# Altium schematic sheet main.SchDoc(main) (continued)
## Library data of the parts (continued)
D3-D7 D11 = 0805 SMD RED LED: Single-color LEDs; LITEON; LTST-C170KRKT; Red; 130 °; 2 V; 0805; Manufacturer: Lite-On; Dominant Wavelength: 631nm; Forward Current: 30mA; Forward Voltage: 2.4V; Lens Color: Clear, Colorless; Lens Style: Clear; Luminous Intensity: 18mcd; Number of Circuits: 1; Peak Wavelength: 639nm; Power Dissipation: 75mW; Reverse Voltage: 5V; Shape: Rectangular; Test Current: 20mA; Viewing Angle: 130°; Case Code (Imperial): 0805; Case Code (Metric): 2012; Case/Package: 0805; Category: LEDs; China RoHS: Compliant; Color: Red; Component Kind: Standard; ComponentLink1Description: https://datasheet.octopart.com:443/LTST-C170KRKT-Lite-On-datasheet-26684674.pdf?src-supplier=Upverter; ComponentLink1URL: https://datasheet.octopart.com:443/LTST-C170KRKT-Lite-On-datasheet-26684674.pdf?src-supplier=Upverter; ComponentLink2Description: https://datasheet.octopart.com:443/LTST-C170KRKT-Lite-On-datasheet-180605146.pdf?src-supplier=IHS; ComponentLink2URL: https://datasheet.octopart.com:443/LTST-C170KRKT-Lite-On-datasheet-180605146.pdf?src-supplier=IHS; ComponentLink3Description: https://datasheet.octopart.com:443/LTST-C170KRKT-Lite-On-datasheet-158436.pdf?src-supplier=_legacy+Avnet; ComponentLink3URL: https://datasheet.octopart.com:443/LTST-C170KRKT-Lite-On-datasheet-158436.pdf?src-supplier=_legacy+Avnet; ComponentLink4Description: https://datasheet.octopart.com:443/LTST-C170KRKT-Lite-On-datasheet-157246157.pdf?src-supplier=ODG+(Origin+Data+Global); ComponentLink4URL: https://datasheet.octopart.com:443/LTST-C170KRKT-Lite-On-datasheet-157246157.pdf?src-supplier=ODG+(Origin+Data+Global); ComponentLink5Description: https://datasheet.octopart.com:443/LTST-C170KRKT-Lite-On-datasheet-166322636.pdf?src-supplier=TME; ComponentLink5URL: https://datasheet.octopart.com:443/LTST-C170KRKT-Lite-On-datasheet-166322636.pdf?src-supplier=TME; ComponentLink6Description: https://datasheet.octopart.com:443/LTST-C170KRKT-Lite-On-datasheet-165573808.pdf?src-supplier=TME; ComponentLink6URL: https://datasheet.octopart.com:443/LTST-C170KRKT-Lite-On-datasheet-165573808.pdf?src-supplier=TME; ComponentLink7Description: https://datasheet.octopart.com:443/LTST-C170KRKT-Lite-On-datasheet-8325281.pdf?src-supplier=Future+Electronics; ComponentLink7URL: https://datasheet.octopart.com:443/LTST-C170KRKT-Lite-On-datasheet-8325281.pdf?src-supplier=Future+Electronics; ComponentLink8Description: https://datasheet.octopart.com:443/LTST-C170KRKT-Lite-On-datasheet-94966.pdf?src-supplier=DigiKey; ComponentLink8URL: https://datasheet.octopart.com:443/LTST-C170KRKT-Lite-On-datasheet-94966.pdf?src-supplier=DigiKey; Depth: 1.6mm; Height: 1.1mm; Illumination Color: Red; Lead Free: Lead Free; Length: 3.2mm; Lens Transparency: Clear; Lifecycle Status: Production; Manufacturer Part Number: LTST-C170KRKT; Max Operating Temperature: 85°C; Min Operating Temperature: -55°C; Mounting Technology: Surface Mount; Number of Elements: 1; Number of LEDs: 1; Number of Terminals: 2; Packaging: Cut Tape; Pins: 2; Power Rating: 75mW; Pricing: 250=0,074, 500=0,063, 1250=0,053, 2500=0,05 (EUR); Radiation Hardening: No; REACH SVHC: Yes; RoHS: Compliant; Schedule B: 8541402000, 8541402000|8541402000|8541402000|8541402000|8541402000; Stock: 27100; Supplier: RS; Supplier Part Number: 6920916P; Terminal Pitch: 2.1mm; Wavelength: 631nm; Width: 1.25mm; Library Name: IMCODR Components.SchLib
  D3-D6: ClassName: LED_SUPPLIES
  D7 D11: ClassName: ESP32_CONN
D8 = 2053-07-SM-RPLF: Surge Arrestor 2-Electrode Miniature Gas Discharge Tube 75VDC 5kA SMD T/R; Manufacturer: Bourns; Fail Short: No; Number of Circuits: 1; Number of Poles: 2; Peak Pulse Current: 3kA; Tolerance: 20%; Case/Package: SMD/SMT; Category: Circuit Protection; China RoHS: Compliant; ClassName: HV_CURRENT_SENSE; Component Kind: Standard; ComponentLink1Description: https://datasheet.octopart.com:443/2053-07-SM-RPLF-Bourns-datasheet-166511578.pdf?src-supplier=IHS; ComponentLink1URL: https://datasheet.octopart.com:443/2053-07-SM-RPLF-Bourns-datasheet-166511578.pdf?src-supplier=IHS; ComponentLink2Description: https://datasheet.octopart.com:443/2053-07-SM-RPLF-Bourns-datasheet-47988657.pdf?src-supplier=Electro+Sonic; ComponentLink2URL: https://datasheet.octopart.com:443/2053-07-SM-RPLF-Bourns-datasheet-47988657.pdf?src-supplier=Electro+Sonic; ComponentLink3Description: https://datasheet.octopart.com:443/2053-07-SM-RPLF-Bourns-datasheet-94104123.pdf?src-supplier=Farnell; ComponentLink3URL: https://datasheet.octopart.com:443/2053-07-SM-RPLF-Bourns-datasheet-94104123.pdf?src-supplier=Farnell; ComponentLink4Description: https://datasheet.octopart.com:443/2053-07-SM-RPLF-Bourns-datasheet-11980459.pdf?src-supplier=Newark; ComponentLink4URL: https://datasheet.octopart.com:443/2053-07-SM-RPLF-Bourns-datasheet-11980459.pdf?src-supplier=Newark; ComponentLink5Description: http://sigma.octopart.com:80/conflict_mineral_statement/Bourns-company-36.pdf?src-supplier=Compliance+Statements; ComponentLink5URL: http://sigma.octopart.com:80/conflict_mineral_statement/Bourns-company-36.pdf?src-supplier=Compliance+Statements; Contact Plating: Nickel; Depth: 4.2mm; Height: 4mm; Length: 4mm; Lifecycle Status: Production; Manufacturer Part Number: 2053-07-SM-RPLF; Max Operating Temperature: 85°C; Min Operating Temperature: -30°C; Mounting Technology: Surface Mount; Number of Terminals: 2; Pricing: 2700=0,323 (EUR); REACH SVHC: Yes; RoHS: Compliant; Stock: 2650; Supplier: RS; Supplier Part Number: 1728471; Termination: SMD/SMT; Library Name: IMCODR Components.SchLib
D9 = CDSOD323-T12SC: ESD protection diodes / TVS diodes; BOURNS; CDSOD323-T12SC; 29.5 V; 14 A; 12 V; 13.3 V; Breakdown Voltage: 13.3V; Clamping Voltage: 29.5V; Direction: Bidirectional; Element Configuration: Single; ESD Protection: Yes; Leakage Current: 1µA; Number of Bidirectional Channels: 1; Peak Pulse Current: 14A; Peak Pulse Power: 400W; Power Line Protection: No; Reverse Standoff Voltage: 12V; Test Current: 1mA; Value: 50pF; Category: TVS Diodes; China RoHS: Compliant; ClassName: HV_CURRENT_SENSE; Component Kind: Standard; ComponentLink1Description: https://datasheet.octopart.com:443/CDSOD323-T12SC-Bourns-datasheet-179966067.pdf?src-supplier=Newark; ComponentLink1URL: https://datasheet.octopart.com:443/CDSOD323-T12SC-Bourns-datasheet-179966067.pdf?src-supplier=Newark; ComponentLink2Description: https://datasheet.octopart.com:443/CDSOD323-T12SC-Bourns-datasheet-37162147.pdf?src-supplier=Newark; ComponentLink2URL: https://datasheet.octopart.com:443/CDSOD323-T12SC-Bourns-datasheet-37162147.pdf?src-supplier=Newark; ComponentLink3Description: https://datasheet.octopart.com:443/CDSOD323-T12SC-Bourns-datasheet-134530207.pdf?src-supplier=Electro+Sonic; ComponentLink3URL: https://datasheet.octopart.com:443/CDSOD323-T12SC-Bourns-datasheet-134530207.pdf?src-supplier=Electro+Sonic; ComponentLink4Description: https://datasheet.octopart.com:443/CDSOD323-T12SC-Bourns-datasheet-11734656.pdf?src-supplier=Burklin+Elektronik; ComponentLink4URL: https://datasheet.octopart.com:443/CDSOD323-T12SC-Bourns-datasheet-11734656.pdf?src-supplier=Burklin+Elektronik; ComponentLink5Description: https://datasheet.octopart.com:443/CDSOD323-T12SC-Bourns-datasheet-114748516.pdf?src-supplier=TME; ComponentLink5URL: https://datasheet.octopart.com:443/CDSOD323-T12SC-Bourns-datasheet-114748516.pdf?src-supplier=TME; ComponentLink6Description: http://sigma.octopart.com:80/conflict_mineral_statement/Bourns-company-36.pdf?src-supplier=Compliance+Statements; ComponentLink6URL: http://sigma.octopart.com:80/conflict_mineral_statement/Bourns-company-36.pdf?src-supplier=Compliance+Statements; Composition: Zener; Contact Plating: Tin; Depth: 1.45mm; Height: 1.14mm; Length: 1.9mm; Lifecycle Status: Production; Manufacturer: Bourns; Manufacturer Part Number: CDSOD323-T12SC; Max Operating Temperature: 150°C; Max Reverse Leakage Current: 1µA; Min Breakdown Voltage: 13.3V; Min Operating Temperature: -55°C; Mounting Technology: Surface Mount; Number of Channels: 1; Number of Elements: 1; Number of Terminals: 2; Packaging: Digi-Reel®; Peak Reverse Current: 1µA; Pins: 2; Polarity: Bidirectional; Pricing: 1=0,685, 10=0,565, 100=0,397, 500=0,325, 1000=0,297, 5000=0,236 (EUR); Radiation Hardening: No; REACH SVHC: Yes; RoHS: Compliant; Stock: 9496; Supplier: Farnell; Supplier Part Number: 1824865; Termination: SMD/SMT; Working Voltage: 12V; Library Name: IMCODR Components.SchLib
D10 = 0805 SMD GREEN: OPTOELECTRONICS - SMD Chip LED - TopView Single Color Chip LED, Green, 571 at 20mA, 18 to approx. 71 at 20mA; Manufacturer: Lite-On; Manufacturer Part Number: LTST-C170KGKT; Dominant Wavelength: 571nm; Forward Current: 30mA; Forward Voltage: 2.4V; Lens Color: Clear, Colorless; Lens Style: Clear; Luminous Intensity: 35mcd; Number of Circuits: 1; Peak Wavelength: 574nm; Power Dissipation: 75mW; Reverse Voltage: 5V; Shape: Rectangular; Viewing Angle: 130°; Case Code (Imperial): 0805; Case Code (Metric): 2012; Case/Package: 0805; Category: LEDs; China RoHS: Compliant; ClassName: HV_SUPPLY; Color: Green; Component Kind: Standard; ComponentLink1Description: https://datasheet.octopart.com:443/LTST-C170KGKT-Lite-On-datasheet-26684672.pdf?src-supplier=Upverter; ComponentLink1URL: https://datasheet.octopart.com:443/LTST-C170KGKT-Lite-On-datasheet-26684672.pdf?src-supplier=Upverter; ComponentLink2Description: https://datasheet.octopart.com:443/LTST-C170KGKT-Lite-On-datasheet-141446389.pdf?src-supplier=ODG+(Origin+Data+Global); ComponentLink2URL: https://datasheet.octopart.com:443/LTST-C170KGKT-Lite-On-datasheet-141446389.pdf?src-supplier=ODG+(Origin+Data+Global); ComponentLink3Description: https://datasheet.octopart.com:443/LTST-C170KGKT-Lite-On-datasheet-180605145.pdf?src-supplier=IHS; ComponentLink3URL: https://datasheet.octopart.com:443/LTST-C170KGKT-Lite-On-datasheet-180605145.pdf?src-supplier=IHS; ComponentLink4Description: https://datasheet.octopart.com:443/LTST-C170KGKT-Lite-On-datasheet-158284.pdf?src-supplier=_legacy+Avnet; ComponentLink4URL: https://datasheet.octopart.com:443/LTST-C170KGKT-Lite-On-datasheet-158284.pdf?src-supplier=_legacy+Avnet; ComponentLink5Description: https://datasheet.octopart.com:443/LTST-C170KGKT-Lite-On-datasheet-166322636.pdf?src-supplier=TME; ComponentLink5URL: https://datasheet.octopart.com:443/LTST-C170KGKT-Lite-On-datasheet-166322636.pdf?src-supplier=TME; ComponentLink6Description: https://datasheet.octopart.com:443/LTST-C170KGKT-Lite-On-datasheet-165573808.pdf?src-supplier=TME; ComponentLink6URL: https://datasheet.octopart.com:443/LTST-C170KGKT-Lite-On-datasheet-165573808.pdf?src-supplier=TME; ComponentLink7Description: https://datasheet.octopart.com:443/LTST-C170KGKT-Lite-On-datasheet-137080776.pdf?src-supplier=X-ON; ComponentLink7URL: https://datasheet.octopart.com:443/LTST-C170KGKT-Lite-On-datasheet-137080776.pdf?src-supplier=X-ON; ComponentLink8Description: https://datasheet.octopart.com:443/LTST-C170KGKT-Lite-On-datasheet-68907.pdf?src-supplier=DigiKey; ComponentLink8URL: https://datasheet.octopart.com:443/LTST-C170KGKT-Lite-On-datasheet-68907.pdf?src-supplier=DigiKey; ComponentLink9Description: https://datasheet.octopart.com:443/LTST-C170KGKT-Lite-On-datasheet-14174950.pdf?src-supplier=iiiC; ComponentLink9URL: https://datasheet.octopart.com:443/LTST-C170KGKT-Lite-On-datasheet-14174950.pdf?src-supplier=iiiC; Depth: 1.25mm; Height: 1.1mm; Illumination Color: Green; Lead Free: Lead Free; Length: 2mm; Lens Transparency: Clear; Lifecycle Status: Production; Max Operating Temperature: 85°C; Min Operating Temperature: -55°C; Mounting Technology: Surface Mount; Number of Elements: 1; Number of LEDs: 1; Number of Terminals: 2; Pins: 2; Power Rating: 75mW; Pricing: 50=0,178, 250=0,082, 500=0,07, 1250=0,06, 2500=0,056 (EUR); Radiation Hardening: No; REACH SVHC: Yes; RoHS: Compliant; Schedule B: 8541402000, 8541402000|8541402000|8541402000|8541402000|8541402000; Stock: 7050; Supplier: RS; Supplier Part Number: 6920907; Terminal Pitch: 2.1mm; Test Current: 20mA; Wavelength: 571nm; Width: 1.25mm; Library Name: IMCODR Components.SchLib
D12 = SS22-E3/5BT: Rectifier Diode, Schottky, 1 Phase, 1 Element, 2A, 20V V(RRM), Silicon, DO-214AA; Manufacturer: Vishay; Element Configuration: Single; Forward Voltage: 500mV; Max Forward Surge Current (Ifsm): 75A; Max Reverse Leakage Current: 400µA; Number of Elements: 1; Average Rectified Current: 2A; Case/Package: SMB; Category: Rectifier Diodes; China RoHS: Non-Compliant; ClassName: POWER_INPUT; Component Kind: Standard; ComponentLink1Description: https://datasheet.octopart.com:443/SS22-E3-5BT-Vishay-datasheet-181475076.pdf?src-supplier=IHS; ComponentLink1URL: https://datasheet.octopart.com:443/SS22-E3-5BT-Vishay-datasheet-181475076.pdf?src-supplier=IHS; ComponentLink2Description: https://datasheet.octopart.com:443/SS22-E3-5BT-Vishay-datasheet-65864839.pdf?src-supplier=Future+Electronics; ComponentLink2URL: https://datasheet.octopart.com:443/SS22-E3-5BT-Vishay-datasheet-65864839.pdf?src-supplier=Future+Electronics; ComponentLink3Description: https://datasheet.octopart.com:443/SS22-E3-5BT-Vishay-datasheet-28809158.pdf?src-supplier=Future+Electronics; ComponentLink3URL: https://datasheet.octopart.com:443/SS22-E3-5BT-Vishay-datasheet-28809158.pdf?src-supplier=Future+Electronics; ComponentLink4Description: http://sigma.octopart.com:80/rohs_statement/Vishay-company-15.pdf?src-supplier=Compliance+Statements; ComponentLink4URL: http://sigma.octopart.com:80/rohs_statement/Vishay-company-15.pdf?src-supplier=Compliance+Statements; Contact Plating: Tin; Forward Current: 2A; Lead Free: Lead Free; Lifecycle Status: Production; Manufacturer Part Number: SS22-E3/5BT; Max Operating Temperature: 125°C; Max Output current: 2A; Max Repetitive Reverse Voltage (Vrrm): 20V; Max Reverse Voltage (DC): 20V; Max Surge Current: 75A; Min Operating Temperature: -65°C; Mounting Technology: Surface Mount; Number of Terminals: 2; Peak Non-Repetitive Surge Current: 75A; Peak Reverse Current: 400µA; Pins: 2; Pricing: 1=0,5, 10=0,343, 100=0,2835, 500=0,21554, 1000=0,19348 (USD); Radiation Hardening: No; REACH SVHC: No; Reverse Standoff Voltage: 20V; RoHS: Compliant; Stock: 3106; Supplier: DigiKey; Supplier Part Number: 112-SS22-E3/5BTCT-ND; Library Name: IMCODR Components.SchLib
ENC1 = PEC11R-4215K-S0024: Mechanical Encoder Rotary Incremental Knurl 0.007 Straight Quadrature Digital Square Wave 24PPR Through Hole PC Pin; Manufacturer: Bourns; Built in Switch: Yes; Current Rating: 10mA; Hole Diameter: 1.8mm; Max Supply Voltage (DC): 5V; Number of Channels: 2; Number of Positions: 24; Output Type: Incremental, Quadrature; Category: Encoders; China RoHS: Compliant; ClassName: ROTARY_ENCODER; Component Kind: Standard; ComponentLink1Description: https://datasheet.octopart.com:443/PEC11R-4215K-S0024-Bourns-datasheet-152588733.pdf?src-supplier=IHS; ComponentLink1URL: https://datasheet.octopart.com:443/PEC11R-4215K-S0024-Bourns-datasheet-152588733.pdf?src-supplier=IHS; ComponentLink2Description: https://datasheet.octopart.com:443/PEC11R-4215K-S0024-Bourns-datasheet-68303416.pdf?src-supplier=Burklin+Elektronik; ComponentLink2URL: https://datasheet.octopart.com:443/PEC11R-4215K-S0024-Bourns-datasheet-68303416.pdf?src-supplier=Burklin+Elektronik; ComponentLink3Description: https://datasheet.octopart.com:443/PEC11R-4215K-S0024-Bourns-datasheet-37162171.pdf?src-supplier=element14+APAC; ComponentLink3URL: https://datasheet.octopart.com:443/PEC11R-4215K-S0024-Bourns-datasheet-37162171.pdf?src-supplier=element14+APAC; ComponentLink4Description: https://datasheet.octopart.com:443/PEC11R-4215K-S0024-Bourns-datasheet-37162172.pdf?src-supplier=TME; ComponentLink4URL: https://datasheet.octopart.com:443/PEC11R-4215K-S0024-Bourns-datasheet-37162172.pdf?src-supplier=TME; ComponentLink5Description: https://datasheet.octopart.com/PEC11R-4215K-S0024-Bourns-datasheet-16722594.pdf; ComponentLink5URL: https://datasheet.octopart.com/PEC11R-4215K-S0024-Bourns-datasheet-16722594.pdf; ComponentLink6Description: http://sigma.octopart.com:80/conflict_mineral_statement/Bourns-company-36.pdf?src-supplier=Compliance+Statements; ComponentLink6URL: http://sigma.octopart.com:80/conflict_mineral_statement/Bourns-company-36.pdf?src-supplier=Compliance+Statements; Detent: Yes; Encoder Type: Incremental; Height: 22.3mm; Housing Material: Metal; Length: 21.5mm; Lifecycle Status: Production; Manufacturer Part Number: PEC11R-4215K-S0024; Max Operating Temperature: 70°C; Min Operating Temperature: -30°C; Mounting Technology: PCB, Panel, Through Hole; Orientation: Vertical; Pricing: 10=1,66, 25=1,56, 100=1,19, 250=1,14 (EUR); Pulses per Revolution: 24; REACH SVHC: Yes; RoHS: Compliant; Schedule B: 8543709655|8543709655|8543709655|8543709655|8543709655; Stock: 710; Supplier: RS; Supplier Part Number: 7377742P; Termination: PC Pin; Voltage Rating (DC): 5V; Width: 12.5mm; Library Name: IMCODR Components.SchLib
HV1 = BPx20504: iSeg BPS series 1/3W High-Voltage supply; ClassName: HV_SUPPLY; Component Kind: Standard; Library Name: IMCODR Components.SchLib
J1 = TBLK4_5P Wurth Elektronik 691137710004: Terminal Block, 5MM Pitch, Horizontal, Pressure Clamp Glow Wire, Pcb, 4PINS, Gr; Category: Terminal Blocks; ClassName: POWER_INPUT; Component Kind: Standard; ComponentLink1Description: https://datasheet.octopart.com:443/691137710004-W%C3%BCrth-Elektronik-datasheet-117282627.pdf?src-supplier=Wurth+Elektronik; ComponentLink1URL: https://datasheet.octopart.com:443/691137710004-W%C3%BCrth-Elektronik-datasheet-117282627.pdf?src-supplier=Wurth+Elektronik; ComponentLink2Description: https://datasheet.octopart.com:443/691137710004-Wurth-Elektronik-datasheet-166323114.pdf?src-supplier=Newark; ComponentLink2URL: https://datasheet.octopart.com:443/691137710004-Wurth-Elektronik-datasheet-166323114.pdf?src-supplier=Newark; Lead Pitch: 5mm; Manufacturer: Wurth Elektronik; Manufacturer Part Number: 691137710004; Packaging: Box; Pins: 4; Pricing: 5=0,73, 25=0,658, 50=0,6, 100=0,548, 250=0,502 (EUR); RoHS: Non-Compliant; Stock: 174; Supplier: RS; Supplier Part Number: 1770041; Library Name: IMCODR Components.SchLib
J2 J3 = CON19X1_2P54: PCB Receptacle, Board-to-Board, 2.54 mm, 1 Rows, 19 Contacts, Through Hole Mount, SSW Series; Manufacturer: Samtec; Manufacturer Lifecycle Status: PRODUCTION; Manufacturer Part Number: SSW-119-01-T-S; Connector Type: Receptacle, Socket; Lead Length: 2.64mm; Number of Contacts: 19; Pitch: 2.54mm; Voltage Rating (AC): 465V; Voltage Rating (DC): 655V; Category: Connectors; ClassName: ESP32_CONN; Color: Black; Component Kind: Standard; ComponentLink1Description: https://datasheet.octopart.com:443/SSW-119-01-T-S-Samtec-datasheet-97292260.pdf?src-supplier=Samtec; ComponentLink1URL: https://datasheet.octopart.com:443/SSW-119-01-T-S-Samtec-datasheet-97292260.pdf?src-supplier=Samtec; ComponentLink2Description: https://sigma.octopart.com:443/97292261/technical_drawing/Samtec-SSW-119-01-T-S.pdf?src-supplier=Samtec; ComponentLink2URL: https://sigma.octopart.com:443/97292261/technical_drawing/Samtec-SSW-119-01-T-S.pdf?src-supplier=Samtec; ComponentLink3Description: https://datasheet.octopart.com:443/SSW-119-01-T-S-Samtec-datasheet-97291133.pdf?src-supplier=element14+APAC; ComponentLink3URL: https://datasheet.octopart.com:443/SSW-119-01-T-S-Samtec-datasheet-97291133.pdf?src-supplier=element14+APAC; ComponentLink4Description: https://datasheet.octopart.com:443/SSW-119-01-T-S-Samtec-datasheet-184263327.pdf?src-supplier=Electro+Sonic; ComponentLink4URL: https://datasheet.octopart.com:443/SSW-119-01-T-S-Samtec-datasheet-184263327.pdf?src-supplier=Electro+Sonic; ComponentLink5Description: https://datasheet.octopart.com:443/SSW-119-01-T-S-Samtec-datasheet-171398496.pdf?src-supplier=JRH+Electronics; ComponentLink5URL: https://datasheet.octopart.com:443/SSW-119-01-T-S-Samtec-datasheet-171398496.pdf?src-supplier=JRH+Electronics; ComponentLink6Description: https://datasheet.octopart.com:443/SSW-119-01-T-S-Samtec-datasheet-153594224.pdf?src-supplier=Sager+Electronics; ComponentLink6URL: https://datasheet.octopart.com:443/SSW-119-01-T-S-Samtec-datasheet-153594224.pdf?src-supplier=Sager+Electronics; ComponentLink7Description: https://datasheet.octopart.com:443/SSW-119-01-T-S-Samtec-datasheet-118235309.pdf?src-supplier=Sager+Electronics; ComponentLink7URL: https://datasheet.octopart.com:443/SSW-119-01-T-S-Samtec-datasheet-118235309.pdf?src-supplier=Sager+Electronics; ComponentLink8Description: https://datasheet.octopart.com/SSW-119-01-T-S-Samtec-datasheet-86818373.pdf; ComponentLink8URL: https://datasheet.octopart.com/SSW-119-01-T-S-Samtec-datasheet-86818373.pdf; ComponentLink9Description: https://datasheet.octopart.com/SSW-119-01-T-S-Samtec-datasheet-17059784.pdf; ComponentLink9URL: https://datasheet.octopart.com/SSW-119-01-T-S-Samtec-datasheet-17059784.pdf; ComponentLink10Description: https://datasheet.octopart.com:443/SSW-119-01-T-S-Samtec-datasheet-62331924.pdf?src-supplier=Newark; ComponentLink10URL: https://datasheet.octopart.com:443/SSW-119-01-T-S-Samtec-datasheet-62331924.pdf?src-supplier=Newark; ComponentLink11Description: https://datasheet.octopart.com:443/SSW-119-01-T-S-Samtec-datasheet-138830832.pdf?src-supplier=Newark; ComponentLink11URL: https://datasheet.octopart.com:443/SSW-119-01-T-S-Samtec-datasheet-138830832.pdf?src-supplier=Newark; ComponentLink12Description: https://datasheet.octopart.com:443/SSW-119-01-T-S-Samtec-datasheet-62317613.pdf?src-supplier=Newark; ComponentLink12URL: https://datasheet.octopart.com:443/SSW-119-01-T-S-Samtec-datasheet-62317613.pdf?src-supplier=Newark; ComponentLink13Description: https://datasheet.octopart.com:443/SSW-119-01-T-S-Samtec-datasheet-21214641.pdf?src-supplier=Newark; ComponentLink13URL: https://datasheet.octopart.com:443/SSW-119-01-T-S-Samtec-datasheet-21214641.pdf?src-supplier=Newark; ComponentLink14Description: https://datasheet.octopart.com:443/SSW-119-01-T-S-Samtec-datasheet-21424861.pdf?src-supplier=Farnell; ComponentLink14URL: https://datasheet.octopart.com:443/SSW-119-01-T-S-Samtec-datasheet-21424861.pdf?src-supplier=Farnell; Contact Material: Bronze; Contact Plating: Tin; Gender: Receptacle; Height: 8.51mm; Housing Color: Black; Housing Material: Plastic; LatestRevisionDate: 17-Jul-2002; LatestRevisionNote: Re-released for DXP Platform.; Lead Free: Lead Free; Lead Pitch: 2.54mm; Length: 48.8mm; Lifecycle Status: Production; Mounting Technology: Through Hole; Number of Positions: 19; Number of Rows: 1; Packaging: Bulk; Pricing: 1=2,33, 10=1,91, 100=1,61, 250=1,53, 500=1,44 (EUR); REACH SVHC: No; RoHS: Compliant; Signal Integrity: Connector; Stock: 109; Supplier: Farnell; Supplier Part Number: 3661308; Termination: Solder; Width: 2.41mm; Library Name: IMCODR Components.SchLib; Publisher: Altium Limited
J4 J5 = HV Connection Hole: Solder-on cable connector for HV; ClassName: HV_SUPPLY; Component Kind: Standard; Library Name: IMCODR Components.SchLib
J6 J8 = CON5X1_2P54: Pin Header, Vertical, Board-to-Board, 2.54 mm, 1 Rows, 5 Contacts, Through Hole, TSW; Manufacturer: Samtec; Manufacturer Lifecycle Status: PRODUCTION; Manufacturer Part Number: TSW-105-07-L-S; Connector Type: Male Pin; Current Rating: 7.6A; Gender: Male; Max Voltage Rating (AC): 550V; Number of Contacts: 5; Pitch: 2.54mm; Category: Headers and Wire Housings; Color: Black; Component Kind: Standard; ComponentLink1Description: https://datasheet.octopart.com:443/TSW-105-07-L-S-Samtec-datasheet-97325576.pdf?src-supplier=Sager+Electronics; ComponentLink1URL: https://datasheet.octopart.com:443/TSW-105-07-L-S-Samtec-datasheet-97325576.pdf?src-supplier=Sager+Electronics; ComponentLink2Description: https://datasheet.octopart.com:443/TSW-105-07-L-S-Samtec-datasheet-151455181.pdf?src-supplier=Sager+Electronics; ComponentLink2URL: https://datasheet.octopart.com:443/TSW-105-07-L-S-Samtec-datasheet-151455181.pdf?src-supplier=Sager+Electronics; ComponentLink3Description: https://sigma.octopart.com:443/97325577/technical_drawing/Samtec-TSW-105-07-L-S.pdf?src-supplier=Samtec; ComponentLink3URL: https://sigma.octopart.com:443/97325577/technical_drawing/Samtec-TSW-105-07-L-S.pdf?src-supplier=Samtec; ComponentLink4Description: https://datasheet.octopart.com:443/TSW-105-07-L-S-Samtec-datasheet-62107121.pdf?src-supplier=Farnell; ComponentLink4URL: https://datasheet.octopart.com:443/TSW-105-07-L-S-Samtec-datasheet-62107121.pdf?src-supplier=Farnell; ComponentLink5Description: https://datasheet.octopart.com:443/TSW-105-07-L-S-Samtec-datasheet-136646178.pdf?src-supplier=Master+Electronics; ComponentLink5URL: https://datasheet.octopart.com:443/TSW-105-07-L-S-Samtec-datasheet-136646178.pdf?src-supplier=Master+Electronics; ComponentLink6Description: https://datasheet.octopart.com:443/TSW-105-07-L-S-Samtec-datasheet-116615235.pdf?src-supplier=Master+Electronics; ComponentLink6URL: https://datasheet.octopart.com:443/TSW-105-07-L-S-Samtec-datasheet-116615235.pdf?src-supplier=Master+Electronics; ComponentLink7Description: https://datasheet.octopart.com:443/TSW-105-07-L-S-Samtec-datasheet-182948906.pdf?src-supplier=JRH+Electronics; ComponentLink7URL: https://datasheet.octopart.com:443/TSW-105-07-L-S-Samtec-datasheet-182948906.pdf?src-supplier=JRH+Electronics; ComponentLink8Description: https://datasheet.octopart.com:443/TSW-105-07-L-S-Samtec-datasheet-160657603.pdf?src-supplier=Toby+Electronics; ComponentLink8URL: https://datasheet.octopart.com:443/TSW-105-07-L-S-Samtec-datasheet-160657603.pdf?src-supplier=Toby+Electronics; ComponentLink9Description: https://datasheet.octopart.com:443/TSW-105-07-L-S-Samtec-datasheet-116539033.pdf?src-supplier=Toby+Electronics; ComponentLink9URL: https://datasheet.octopart.com:443/TSW-105-07-L-S-Samtec-datasheet-116539033.pdf?src-supplier=Toby+Electronics; ComponentLink10Description: https://datasheet.octopart.com:443/TSW-105-07-L-S-Samtec-datasheet-116536310.pdf?src-supplier=Toby+Electronics; ComponentLink10URL: https://datasheet.octopart.com:443/TSW-105-07-L-S-Samtec-datasheet-116536310.pdf?src-supplier=Toby+Electronics; ComponentLink11Description: https://datasheet.octopart.com:443/TSW-105-07-L-S-Samtec-datasheet-118235302.pdf?src-supplier=Electro+Sonic; ComponentLink11URL: https://datasheet.octopart.com:443/TSW-105-07-L-S-Samtec-datasheet-118235302.pdf?src-supplier=Electro+Sonic; ComponentLink12Description: https://datasheet.octopart.com/TSW-105-07-L-S-Samtec-datasheet-86818365.pdf; ComponentLink12URL: https://datasheet.octopart.com/TSW-105-07-L-S-Samtec-datasheet-86818365.pdf; ComponentLink13Description: https://datasheet.octopart.com:443/TSW-105-07-L-S-Samtec-datasheet-11047283.pdf?src-supplier=Newark; ComponentLink13URL: https://datasheet.octopart.com:443/TSW-105-07-L-S-Samtec-datasheet-11047283.pdf?src-supplier=Newark; Contact Material: Bronze; Contact Mating Length: 5.842mm; Contact Plating: Gold; Depth: 2.54mm; Housing Color: Black; Housing Material: Polyester; LatestRevisionDate: 17-Jul-2002; LatestRevisionNote: Re-released for DXP Platform.; Lead Free: Lead Free; Lead Pitch: 2.54mm; Length: 12.7mm; Lifecycle Status: Production; Max Current Rating: 7.6A; Max Operating Temperature: 125°C; Min Operating Temperature: -55°C; Mounting Technology: Through Hole; Number of Positions: 5; Number of Rows: 1; Orientation: Straight; Packaging: Bulk; Pricing: 10=0,379, 100=0,33, 500=0,289, 1000=0,24, 2500=0,181 (EUR); Radiation Hardening: No; REACH SVHC: No; RoHS: Compliant; Signal Integrity: Connector; Stock: 92; Supplier: Farnell; Supplier Part Number: 3515082; Termination: Solder; Voltage Rating: 550V; Width: 2.54mm; Library Name: IMCODR Components.SchLib; Publisher: Altium Limited
  J6: ClassName: ROTARY_ENCODER
  J8: ClassName: RS232_DEBUG
J7 = CON8X2_2P54: Pin Header, Board-to-Board, 2.54 mm, 2 Rows, 16 Contacts, Through Hole, TSW Series; Manufacturer: Samtec; Manufacturer Lifecycle Status: PRODUCTION; Manufacturer Part Number: TSW-108-07-L-D; Connector Type: Male Pin; Current Rating: 7.6A; Gender: Male; Max Voltage Rating (AC): 550V; Number of Contacts: 16; Pitch: 2.54mm; Category: Headers and Wire Housings; ClassName: IO_EXPANSION; Color: Black; Component Kind: Standard; ComponentLink1Description: https://datasheet.octopart.com:443/TSW-108-07-L-D-Samtec-datasheet-97325576.pdf?src-supplier=Sager+Electronics; ComponentLink1URL: https://datasheet.octopart.com:443/TSW-108-07-L-D-Samtec-datasheet-97325576.pdf?src-supplier=Sager+Electronics; ComponentLink2Description: https://datasheet.octopart.com:443/TSW-108-07-L-D-Samtec-datasheet-151455181.pdf?src-supplier=Sager+Electronics; ComponentLink2URL: https://datasheet.octopart.com:443/TSW-108-07-L-D-Samtec-datasheet-151455181.pdf?src-supplier=Sager+Electronics; ComponentLink3Description: https://sigma.octopart.com:443/97325577/technical_drawing/Samtec-TSW-108-07-L-D.pdf?src-supplier=Samtec; ComponentLink3URL: https://sigma.octopart.com:443/97325577/technical_drawing/Samtec-TSW-108-07-L-D.pdf?src-supplier=Samtec; ComponentLink4Description: https://datasheet.octopart.com:443/TSW-108-07-L-D-Samtec-datasheet-62107121.pdf?src-supplier=Farnell; ComponentLink4URL: https://datasheet.octopart.com:443/TSW-108-07-L-D-Samtec-datasheet-62107121.pdf?src-supplier=Farnell; ComponentLink5Description: https://datasheet.octopart.com:443/TSW-108-07-L-D-Samtec-datasheet-136646178.pdf?src-supplier=Master+Electronics; ComponentLink5URL: https://datasheet.octopart.com:443/TSW-108-07-L-D-Samtec-datasheet-136646178.pdf?src-supplier=Master+Electronics; ComponentLink6Description: https://datasheet.octopart.com:443/TSW-108-07-L-D-Samtec-datasheet-116615235.pdf?src-supplier=Electro+Sonic; ComponentLink6URL: https://datasheet.octopart.com:443/TSW-108-07-L-D-Samtec-datasheet-116615235.pdf?src-supplier=Electro+Sonic; ComponentLink7Description: https://datasheet.octopart.com:443/TSW-108-07-L-D-Samtec-datasheet-182948906.pdf?src-supplier=JRH+Electronics; ComponentLink7URL: https://datasheet.octopart.com:443/TSW-108-07-L-D-Samtec-datasheet-182948906.pdf?src-supplier=JRH+Electronics; ComponentLink8Description: https://datasheet.octopart.com:443/TSW-108-07-L-D-Samtec-datasheet-160657603.pdf?src-supplier=Toby+Electronics; ComponentLink8URL: https://datasheet.octopart.com:443/TSW-108-07-L-D-Samtec-datasheet-160657603.pdf?src-supplier=Toby+Electronics; ComponentLink9Description: https://datasheet.octopart.com:443/TSW-108-07-L-D-Samtec-datasheet-116539033.pdf?src-supplier=Toby+Electronics; ComponentLink9URL: https://datasheet.octopart.com:443/TSW-108-07-L-D-Samtec-datasheet-116539033.pdf?src-supplier=Toby+Electronics; ComponentLink10Description: https://datasheet.octopart.com:443/TSW-108-07-L-D-Samtec-datasheet-116536310.pdf?src-supplier=Toby+Electronics; ComponentLink10URL: https://datasheet.octopart.com:443/TSW-108-07-L-D-Samtec-datasheet-116536310.pdf?src-supplier=Toby+Electronics; ComponentLink11Description: https://datasheet.octopart.com/TSW-108-07-L-D-Samtec-datasheet-86818365.pdf; ComponentLink11URL: https://datasheet.octopart.com/TSW-108-07-L-D-Samtec-datasheet-86818365.pdf; ComponentLink12Description: https://datasheet.octopart.com:443/TSW-108-07-L-D-Samtec-datasheet-11047283.pdf?src-supplier=Newark; ComponentLink12URL: https://datasheet.octopart.com:443/TSW-108-07-L-D-Samtec-datasheet-11047283.pdf?src-supplier=Newark; Contact Material: Bronze; Contact Mating Length: 5.842mm; Contact Plating: Gold; Depth: 5.08mm; Housing Color: Black; Housing Material: Polyester; LatestRevisionDate: 17-Jul-2002; LatestRevisionNote: Re-released for DXP Platform.; Lead Free: Lead Free; Lead Pitch: 2.54mm; Length: 20.32mm; Lifecycle Status: Production; Max Current Rating: 7.6A; Max Operating Temperature: 125°C; Min Operating Temperature: -55°C; Mounting Technology: Through Hole; Number of Positions: 16; Number of Rows: 2; Orientation: Straight; Packaging: Bulk; Pricing: 1=1,06, 10=0,968, 100=0,876, 500=0,733, 1000=0,644 (EUR); Radiation Hardening: No; REACH SVHC: No; RoHS: Compliant; Row Spacing: 2.54mm; Signal Integrity: Connector; Stock: 97; Supplier: Farnell; Supplier Part Number: 2984454; Termination: Solder; Voltage Rating: 550V; Width: 5.08mm; Library Name: IMCODR Components.SchLib; Publisher: Altium Limited
J9 = CON4X1_2P54: Pin Header, Board-to-Board, 2.54 mm, 1 Rows, 4 Contacts, Through Hole, TSW; Manufacturer: Samtec; Manufacturer Lifecycle Status: PRODUCTION; Manufacturer Part Number: TSW-104-07-L-S; Connector Type: Male Pin; Current Rating: 7.6A; Gender: Male; Max Current Rating: 7A; Max Voltage Rating (AC): 550V; Number of Contacts: 4; Pitch: 2.54mm; Category: Headers and Wire Housings; ClassName: I2C_EXPANSION; Color: Black; Component Kind: Standard; ComponentLink1Description: https://datasheet.octopart.com:443/TSW-104-07-L-S-Samtec-datasheet-97325576.pdf?src-supplier=Newark; ComponentLink1URL: https://datasheet.octopart.com:443/TSW-104-07-L-S-Samtec-datasheet-97325576.pdf?src-supplier=Newark; ComponentLink2Description: https://datasheet.octopart.com:443/TSW-104-07-L-S-Samtec-datasheet-62107121.pdf?src-supplier=Newark; ComponentLink2URL: https://datasheet.octopart.com:443/TSW-104-07-L-S-Samtec-datasheet-62107121.pdf?src-supplier=Newark; ComponentLink3Description: https://datasheet.octopart.com:443/TSW-104-07-L-S-Samtec-datasheet-11047283.pdf?src-supplier=Newark; ComponentLink3URL: https://datasheet.octopart.com:443/TSW-104-07-L-S-Samtec-datasheet-11047283.pdf?src-supplier=Newark; ComponentLink4Description: https://sigma.octopart.com:443/97325577/technical_drawing/Samtec-TSW-104-07-L-S.pdf?src-supplier=Samtec; ComponentLink4URL: https://sigma.octopart.com:443/97325577/technical_drawing/Samtec-TSW-104-07-L-S.pdf?src-supplier=Samtec; ComponentLink5Description: https://datasheet.octopart.com:443/TSW-104-07-L-S-Samtec-datasheet-150856372.pdf?src-supplier=Electro+Sonic; ComponentLink5URL: https://datasheet.octopart.com:443/TSW-104-07-L-S-Samtec-datasheet-150856372.pdf?src-supplier=Electro+Sonic; ComponentLink6Description: https://datasheet.octopart.com:443/TSW-104-07-L-S-Samtec-datasheet-32864818.pdf?src-supplier=Electro+Sonic; ComponentLink6URL: https://datasheet.octopart.com:443/TSW-104-07-L-S-Samtec-datasheet-32864818.pdf?src-supplier=Electro+Sonic; ComponentLink7Description: https://datasheet.octopart.com:443/TSW-104-07-L-S-Samtec-datasheet-11542832.pdf?src-supplier=Electro+Sonic; ComponentLink7URL: https://datasheet.octopart.com:443/TSW-104-07-L-S-Samtec-datasheet-11542832.pdf?src-supplier=Electro+Sonic; ComponentLink8Description: https://datasheet.octopart.com:443/TSW-104-07-L-S-Samtec-datasheet-116615235.pdf?src-supplier=Master+Electronics; ComponentLink8URL: https://datasheet.octopart.com:443/TSW-104-07-L-S-Samtec-datasheet-116615235.pdf?src-supplier=Master+Electronics; ComponentLink9Description: https://datasheet.octopart.com:443/TSW-104-07-L-S-Samtec-datasheet-182948906.pdf?src-supplier=JRH+Electronics; ComponentLink9URL: https://datasheet.octopart.com:443/TSW-104-07-L-S-Samtec-datasheet-182948906.pdf?src-supplier=JRH+Electronics; ComponentLink10Description: https://datasheet.octopart.com:443/TSW-104-07-L-S-Samtec-datasheet-160657603.pdf?src-supplier=Toby+Electronics; ComponentLink10URL: https://datasheet.octopart.com:443/TSW-104-07-L-S-Samtec-datasheet-160657603.pdf?src-supplier=Toby+Electronics; ComponentLink11Description: https://datasheet.octopart.com:443/TSW-104-07-L-S-Samtec-datasheet-116539033.pdf?src-supplier=Toby+Electronics; ComponentLink11URL: https://datasheet.octopart.com:443/TSW-104-07-L-S-Samtec-datasheet-116539033.pdf?src-supplier=Toby+Electronics; ComponentLink12Description: https://datasheet.octopart.com:443/TSW-104-07-L-S-Samtec-datasheet-116536310.pdf?src-supplier=Toby+Electronics; ComponentLink12URL: https://datasheet.octopart.com:443/TSW-104-07-L-S-Samtec-datasheet-116536310.pdf?src-supplier=Toby+Electronics; ComponentLink13Description: https://datasheet.octopart.com:443/TSW-104-07-L-S-Samtec-datasheet-151455181.pdf?src-supplier=Sager+Electronics; ComponentLink13URL: https://datasheet.octopart.com:443/TSW-104-07-L-S-Samtec-datasheet-151455181.pdf?src-supplier=Sager+Electronics; ComponentLink14Description: https://datasheet.octopart.com/TSW-104-07-L-S-Samtec-datasheet-86818365.pdf; ComponentLink14URL: https://datasheet.octopart.com/TSW-104-07-L-S-Samtec-datasheet-86818365.pdf; Contact Material: Bronze; Contact Mating Length: 5.842mm; Contact Plating: Gold; Depth: 2.54mm; Housing Color: Black; Housing Material: Polyester; LatestRevisionDate: 17-Jul-2002; LatestRevisionNote: Re-released for DXP Platform.; Lead Free: Lead Free; Lead Pitch: 2.54mm; Length: 10.16mm; Lifecycle Status: Production; Max Operating Temperature: 125°C; Min Operating Temperature: -55°C; Mounting Technology: Through Hole; Number of Positions: 4; Number of Rows: 1; Orientation: Straight; Packaging: Bulk; Pricing: 10=0,299, 100=0,275, 500=0,241, 1000=0,22, 2500=0,193 (EUR); Radiation Hardening: No; REACH SVHC: No; RoHS: Compliant; Signal Integrity: Connector; Stock: 140; Supplier: Farnell; Supplier Part Number: 2856694; Termination: Solder; Voltage Rating: 550V; Width: 2.54mm; Library Name: IMCODR Components.SchLib; Publisher: Altium Limited
J10 = CON8X1_2P54: Pin Header, Board-to-Board, 2.54 mm, 1 Rows, 8 Contacts, Through Hole, TSW Series; Manufacturer: Samtec; Manufacturer Lifecycle Status: PRODUCTION; Manufacturer Part Number: TSW-108-07-L-S; Connector Type: Male Pin; Current Rating: 7.6A; Gender: Male; Max Voltage Rating (AC): 550V; Number of Contacts: 8; Pitch: 2.54mm; Category: Headers and Wire Housings; ClassName: TFT_CONN; Color: Black; Component Kind: Standard; ComponentLink1Description: https://datasheet.octopart.com:443/TSW-108-07-L-S-Samtec-datasheet-97325576.pdf?src-supplier=Sager+Electronics; ComponentLink1URL: https://datasheet.octopart.com:443/TSW-108-07-L-S-Samtec-datasheet-97325576.pdf?src-supplier=Sager+Electronics; ComponentLink2Description: https://datasheet.octopart.com:443/TSW-108-07-L-S-Samtec-datasheet-151455181.pdf?src-supplier=Sager+Electronics; ComponentLink2URL: https://datasheet.octopart.com:443/TSW-108-07-L-S-Samtec-datasheet-151455181.pdf?src-supplier=Sager+Electronics; ComponentLink3Description: https://sigma.octopart.com:443/97325577/technical_drawing/Samtec-TSW-108-07-L-S.pdf?src-supplier=Samtec; ComponentLink3URL: https://sigma.octopart.com:443/97325577/technical_drawing/Samtec-TSW-108-07-L-S.pdf?src-supplier=Samtec; ComponentLink4Description: https://datasheet.octopart.com:443/TSW-108-07-L-S-Samtec-datasheet-62107121.pdf?src-supplier=Farnell; ComponentLink4URL: https://datasheet.octopart.com:443/TSW-108-07-L-S-Samtec-datasheet-62107121.pdf?src-supplier=Farnell; ComponentLink5Description: https://datasheet.octopart.com:443/TSW-108-07-L-S-Samtec-datasheet-150856372.pdf?src-supplier=Electro+Sonic; ComponentLink5URL: https://datasheet.octopart.com:443/TSW-108-07-L-S-Samtec-datasheet-150856372.pdf?src-supplier=Electro+Sonic; ComponentLink6Description: https://datasheet.octopart.com:443/TSW-108-07-L-S-Samtec-datasheet-32864818.pdf?src-supplier=Electro+Sonic; ComponentLink6URL: https://datasheet.octopart.com:443/TSW-108-07-L-S-Samtec-datasheet-32864818.pdf?src-supplier=Electro+Sonic; ComponentLink7Description: https://datasheet.octopart.com:443/TSW-108-07-L-S-Samtec-datasheet-21214474.pdf?src-supplier=Electro+Sonic; ComponentLink7URL: https://datasheet.octopart.com:443/TSW-108-07-L-S-Samtec-datasheet-21214474.pdf?src-supplier=Electro+Sonic; ComponentLink8Description: https://datasheet.octopart.com:443/TSW-108-07-L-S-Samtec-datasheet-116615235.pdf?src-supplier=Master+Electronics; ComponentLink8URL: https://datasheet.octopart.com:443/TSW-108-07-L-S-Samtec-datasheet-116615235.pdf?src-supplier=Master+Electronics; ComponentLink9Description: https://datasheet.octopart.com:443/TSW-108-07-L-S-Samtec-datasheet-182948906.pdf?src-supplier=JRH+Electronics; ComponentLink9URL: https://datasheet.octopart.com:443/TSW-108-07-L-S-Samtec-datasheet-182948906.pdf?src-supplier=JRH+Electronics; ComponentLink10Description: https://datasheet.octopart.com:443/TSW-108-07-L-S-Samtec-datasheet-160657603.pdf?src-supplier=Toby+Electronics; ComponentLink10URL: https://datasheet.octopart.com:443/TSW-108-07-L-S-Samtec-datasheet-160657603.pdf?src-supplier=Toby+Electronics; ComponentLink11Description: https://datasheet.octopart.com:443/TSW-108-07-L-S-Samtec-datasheet-116539033.pdf?src-supplier=Toby+Electronics; ComponentLink11URL: https://datasheet.octopart.com:443/TSW-108-07-L-S-Samtec-datasheet-116539033.pdf?src-supplier=Toby+Electronics; ComponentLink12Description: https://datasheet.octopart.com:443/TSW-108-07-L-S-Samtec-datasheet-116536310.pdf?src-supplier=Toby+Electronics; ComponentLink12URL: https://datasheet.octopart.com:443/TSW-108-07-L-S-Samtec-datasheet-116536310.pdf?src-supplier=Toby+Electronics; ComponentLink13Description: https://datasheet.octopart.com/TSW-108-07-L-S-Samtec-datasheet-86818365.pdf; ComponentLink13URL: https://datasheet.octopart.com/TSW-108-07-L-S-Samtec-datasheet-86818365.pdf; ComponentLink14Description: https://datasheet.octopart.com:443/TSW-108-07-L-S-Samtec-datasheet-11047283.pdf?src-supplier=Newark; ComponentLink14URL: https://datasheet.octopart.com:443/TSW-108-07-L-S-Samtec-datasheet-11047283.pdf?src-supplier=Newark; ComponentLink15Description: https://datasheet.octopart.com:443/TSW-108-07-L-S-Samtec-datasheet-5313500.pdf?src-supplier=_legacy+Avnet; ComponentLink15URL: https://datasheet.octopart.com:443/TSW-108-07-L-S-Samtec-datasheet-5313500.pdf?src-supplier=_legacy+Avnet; Contact Material: Bronze; Contact Mating Length: 5.842mm; Contact Plating: Gold; Depth: 2.54mm; Housing Color: Black; Housing Material: Polyester; LatestRevisionDate: 17-Jul-2002; LatestRevisionNote: Re-released for DXP Platform.; Lead Free: Lead Free; Lead Pitch: 2.54mm; Length: 20.32mm; Lifecycle Status: Production; Max Current Rating: 7.6A; Max Operating Temperature: 125°C; Min Operating Temperature: -55°C; Mounting Technology: Through Hole; Number of Positions: 8; Number of Rows: 1; Orientation: Straight; Packaging: Bulk; Pricing: 10=0,854, 50=0,715, 100=0,642, 250=0,634, 500=0,53 (EUR); Radiation Hardening: No; REACH SVHC: No; RoHS: Compliant; Signal Integrity: Connector; Stock: 99; Supplier: Farnell; Supplier Part Number: 2984523; Termination: Solder; Voltage Rating: 550V; Width: 2.54mm; Library Name: IMCODR Components.SchLib; Publisher: Altium Limited
JP1-JP3 JP5 JP6 JP10 = CON2X1_2P54: Pin Header, Board-to-Board, 2.54 mm, 1 Rows, 2 Contacts, Through Hole, TSW Series; Manufacturer: Samtec; Manufacturer Lifecycle Status: PRODUCTION; Manufacturer Part Number: TSW-102-07-L-S; Connector Type: Male Pin; Current Rating: 7.6A; Gender: Male; Max Voltage Rating (AC): 550V; Number of Contacts: 2; Pitch: 2.54mm; Category: Headers and Wire Housings; Color: Black; Component Kind: Standard; ComponentLink1Description: https://datasheet.octopart.com:443/TSW-102-07-L-S-Samtec-datasheet-142046629.pdf?src-supplier=Upverter; ComponentLink1URL: https://datasheet.octopart.com:443/TSW-102-07-L-S-Samtec-datasheet-142046629.pdf?src-supplier=Upverter; ComponentLink2Description: https://sigma.octopart.com:443/14433679/technical_drawing/Samtec-TSW-102-07-L-S.pdf?src-supplier=Upverter; ComponentLink2URL: https://sigma.octopart.com:443/14433679/technical_drawing/Samtec-TSW-102-07-L-S.pdf?src-supplier=Upverter; ComponentLink3Description: https://datasheet.octopart.com:443/TSW-102-07-L-S-Samtec-datasheet-97325576.pdf?src-supplier=Newark; ComponentLink3URL: https://datasheet.octopart.com:443/TSW-102-07-L-S-Samtec-datasheet-97325576.pdf?src-supplier=Newark; ComponentLink4Description: https://datasheet.octopart.com:443/TSW-102-07-L-S-Samtec-datasheet-11047283.pdf?src-supplier=Newark; ComponentLink4URL: https://datasheet.octopart.com:443/TSW-102-07-L-S-Samtec-datasheet-11047283.pdf?src-supplier=Newark; ComponentLink5Description: https://sigma.octopart.com:443/97325577/technical_drawing/Samtec-TSW-102-07-L-S.pdf?src-supplier=Samtec; ComponentLink5URL: https://sigma.octopart.com:443/97325577/technical_drawing/Samtec-TSW-102-07-L-S.pdf?src-supplier=Samtec; ComponentLink6Description: https://datasheet.octopart.com:443/TSW-102-07-L-S-Samtec-datasheet-62107121.pdf?src-supplier=Farnell; ComponentLink6URL: https://datasheet.octopart.com:443/TSW-102-07-L-S-Samtec-datasheet-62107121.pdf?src-supplier=Farnell; ComponentLink7Description: https://datasheet.octopart.com:443/TSW-102-07-L-S-Samtec-datasheet-150856372.pdf?src-supplier=Electro+Sonic; ComponentLink7URL: https://datasheet.octopart.com:443/TSW-102-07-L-S-Samtec-datasheet-150856372.pdf?src-supplier=Electro+Sonic; ComponentLink8Description: https://datasheet.octopart.com:443/TSW-102-07-L-S-Samtec-datasheet-62282237.pdf?src-supplier=Electro+Sonic; ComponentLink8URL: https://datasheet.octopart.com:443/TSW-102-07-L-S-Samtec-datasheet-62282237.pdf?src-supplier=Electro+Sonic; ComponentLink9Description: https://datasheet.octopart.com:443/TSW-102-07-L-S-Samtec-datasheet-41642934.pdf?src-supplier=Electro+Sonic; ComponentLink9URL: https://datasheet.octopart.com:443/TSW-102-07-L-S-Samtec-datasheet-41642934.pdf?src-supplier=Electro+Sonic; ComponentLink10Description: https://datasheet.octopart.com:443/TSW-102-07-L-S-Samtec-datasheet-32864818.pdf?src-supplier=Electro+Sonic; ComponentLink10URL: https://datasheet.octopart.com:443/TSW-102-07-L-S-Samtec-datasheet-32864818.pdf?src-supplier=Electro+Sonic; ComponentLink11Description: https://datasheet.octopart.com:443/TSW-102-07-L-S-Samtec-datasheet-116615235.pdf?src-supplier=Master+Electronics; ComponentLink11URL: https://datasheet.octopart.com:443/TSW-102-07-L-S-Samtec-datasheet-116615235.pdf?src-supplier=Master+Electronics; ComponentLink12Description: https://datasheet.octopart.com:443/TSW-102-07-L-S-Samtec-datasheet-180258786.pdf?src-supplier=JRH+Electronics; ComponentLink12URL: https://datasheet.octopart.com:443/TSW-102-07-L-S-Samtec-datasheet-180258786.pdf?src-supplier=JRH+Electronics; ComponentLink13Description: https://datasheet.octopart.com:443/TSW-102-07-L-S-Samtec-datasheet-160657603.pdf?src-supplier=Toby+Electronics; ComponentLink13URL: https://datasheet.octopart.com:443/TSW-102-07-L-S-Samtec-datasheet-160657603.pdf?src-supplier=Toby+Electronics; ComponentLink14Description: https://datasheet.octopart.com:443/TSW-102-07-L-S-Samtec-datasheet-116539033.pdf?src-supplier=Toby+Electronics; ComponentLink14URL: https://datasheet.octopart.com:443/TSW-102-07-L-S-Samtec-datasheet-116539033.pdf?src-supplier=Toby+Electronics; ComponentLink15Description: https://datasheet.octopart.com:443/TSW-102-07-L-S-Samtec-datasheet-116536310.pdf?src-supplier=Toby+Electronics; ComponentLink15URL: https://datasheet.octopart.com:443/TSW-102-07-L-S-Samtec-datasheet-116536310.pdf?src-supplier=Toby+Electronics; ComponentLink16Description: https://datasheet.octopart.com:443/TSW-102-07-L-S-Samtec-datasheet-151455181.pdf?src-supplier=Sager+Electronics; ComponentLink16URL: https://datasheet.octopart.com:443/TSW-102-07-L-S-Samtec-datasheet-151455181.pdf?src-supplier=Sager+Electronics; ComponentLink17Description: https://datasheet.octopart.com/TSW-102-07-L-S-Samtec-datasheet-86818365.pdf; ComponentLink17URL: https://datasheet.octopart.com/TSW-102-07-L-S-Samtec-datasheet-86818365.pdf; Contact Material: Gold, Nickel; Contact Mating Length: 5.842mm; Contact Plating: Gold; Depth: 2.54mm; Height: 8.71mm; Housing Color: Black; Housing Material: Polyester; LatestRevisionDate: 17-Jul-2002; LatestRevisionNote: Re-released for DXP Platform.; Lead Free: Lead Free; Lead Pitch: 2.54mm; Lifecycle Status: Production; Max Current Rating: 7.6A; Max Operating Temperature: 125°C; Min Operating Temperature: -55°C; Mounting Technology: Through Hole; Number of Positions: 2; Number of Rows: 1; Orientation: Straight; Pins: 2; Pricing: 100=0,165, 500=0,119, 1000=0,111, 2500=0,103, 5000=0,0804 (EUR); Radiation Hardening: No; REACH SVHC: No; RoHS: Compliant; Signal Integrity: Connector; Stock: 9454; Supplier: Farnell; Supplier Part Number: 2667448; Termination: Solder; Voltage Rating: 550V; Width: 2.54mm; Library Name: IMCODR Components.SchLib; Publisher: Altium Limited
  JP1: ClassName: POWER_INPUT
  JP10: ClassName: HV_SUPPLY
JP4 JP7-JP9 JP11 = CON3X1_2P54: Pin Header, Board-to-Board, 2.54 mm, 1 Rows, 3 Contacts, Through Hole, TSW Series; Manufacturer: Samtec; Manufacturer Lifecycle Status: PRODUCTION; Manufacturer Part Number: TSW-103-07-L-S; Connector Type: Male Pin; Current Rating: 7.6A; Gender: Male; Max Voltage Rating (AC): 550V; Number of Contacts: 3; Pitch: 2.54mm; Category: Headers and Wire Housings; Color: Black; Component Kind: Standard; ComponentLink1Description: https://datasheet.octopart.com:443/TSW-103-07-L-S-Samtec-datasheet-97325576.pdf?src-supplier=Sager+Electronics; ComponentLink1URL: https://datasheet.octopart.com:443/TSW-103-07-L-S-Samtec-datasheet-97325576.pdf?src-supplier=Sager+Electronics; ComponentLink2Description: https://datasheet.octopart.com:443/TSW-103-07-L-S-Samtec-datasheet-151455181.pdf?src-supplier=Sager+Electronics; ComponentLink2URL: https://datasheet.octopart.com:443/TSW-103-07-L-S-Samtec-datasheet-151455181.pdf?src-supplier=Sager+Electronics; ComponentLink3Description: https://datasheet.octopart.com:443/TSW-103-07-L-S-Samtec-datasheet-13503496.pdf?src-supplier=Upverter; ComponentLink3URL: https://datasheet.octopart.com:443/TSW-103-07-L-S-Samtec-datasheet-13503496.pdf?src-supplier=Upverter; ComponentLink4Description: https://sigma.octopart.com:443/97325577/technical_drawing/Samtec-TSW-103-07-L-S.pdf?src-supplier=Newark; ComponentLink4URL: https://sigma.octopart.com:443/97325577/technical_drawing/Samtec-TSW-103-07-L-S.pdf?src-supplier=Newark; ComponentLink5Description: https://datasheet.octopart.com:443/TSW-103-07-L-S-Samtec-datasheet-62107121.pdf?src-supplier=Newark; ComponentLink5URL: https://datasheet.octopart.com:443/TSW-103-07-L-S-Samtec-datasheet-62107121.pdf?src-supplier=Newark; ComponentLink6Description: https://datasheet.octopart.com:443/TSW-103-07-L-S-Samtec-datasheet-11047283.pdf?src-supplier=Newark; ComponentLink6URL: https://datasheet.octopart.com:443/TSW-103-07-L-S-Samtec-datasheet-11047283.pdf?src-supplier=Newark; ComponentLink7Description: https://datasheet.octopart.com:443/TSW-103-07-L-S-Samtec-datasheet-150856372.pdf?src-supplier=Electro+Sonic; ComponentLink7URL: https://datasheet.octopart.com:443/TSW-103-07-L-S-Samtec-datasheet-150856372.pdf?src-supplier=Electro+Sonic; ComponentLink8Description: https://datasheet.octopart.com:443/TSW-103-07-L-S-Samtec-datasheet-62282237.pdf?src-supplier=Electro+Sonic; ComponentLink8URL: https://datasheet.octopart.com:443/TSW-103-07-L-S-Samtec-datasheet-62282237.pdf?src-supplier=Electro+Sonic; ComponentLink9Description: https://datasheet.octopart.com:443/TSW-103-07-L-S-Samtec-datasheet-41642934.pdf?src-supplier=Electro+Sonic; ComponentLink9URL: https://datasheet.octopart.com:443/TSW-103-07-L-S-Samtec-datasheet-41642934.pdf?src-supplier=Electro+Sonic; ComponentLink10Description: https://datasheet.octopart.com:443/TSW-103-07-L-S-Samtec-datasheet-32864818.pdf?src-supplier=Electro+Sonic; ComponentLink10URL: https://datasheet.octopart.com:443/TSW-103-07-L-S-Samtec-datasheet-32864818.pdf?src-supplier=Electro+Sonic; ComponentLink11Description: https://datasheet.octopart.com:443/TSW-103-07-L-S-Samtec-datasheet-116615235.pdf?src-supplier=Master+Electronics; ComponentLink11URL: https://datasheet.octopart.com:443/TSW-103-07-L-S-Samtec-datasheet-116615235.pdf?src-supplier=Master+Electronics; ComponentLink12Description: https://datasheet.octopart.com:443/TSW-103-07-L-S-Samtec-datasheet-180258786.pdf?src-supplier=JRH+Electronics; ComponentLink12URL: https://datasheet.octopart.com:443/TSW-103-07-L-S-Samtec-datasheet-180258786.pdf?src-supplier=JRH+Electronics; ComponentLink13Description: https://datasheet.octopart.com:443/TSW-103-07-L-S-Samtec-datasheet-160657603.pdf?src-supplier=Toby+Electronics; ComponentLink13URL: https://datasheet.octopart.com:443/TSW-103-07-L-S-Samtec-datasheet-160657603.pdf?src-supplier=Toby+Electronics; ComponentLink14Description: https://datasheet.octopart.com:443/TSW-103-07-L-S-Samtec-datasheet-116539033.pdf?src-supplier=Toby+Electronics; ComponentLink14URL: https://datasheet.octopart.com:443/TSW-103-07-L-S-Samtec-datasheet-116539033.pdf?src-supplier=Toby+Electronics; ComponentLink15Description: https://datasheet.octopart.com:443/TSW-103-07-L-S-Samtec-datasheet-116536310.pdf?src-supplier=Toby+Electronics; ComponentLink15URL: https://datasheet.octopart.com:443/TSW-103-07-L-S-Samtec-datasheet-116536310.pdf?src-supplier=Toby+Electronics; ComponentLink16Description: https://datasheet.octopart.com/TSW-103-07-L-S-Samtec-datasheet-86818365.pdf; ComponentLink16URL: https://datasheet.octopart.com/TSW-103-07-L-S-Samtec-datasheet-86818365.pdf; ComponentLink17Description: https://datasheet.octopart.com:443/TSW-103-07-L-S-Samtec-datasheet-11542832.pdf?src-supplier=Arrow+Electronics; ComponentLink17URL: https://datasheet.octopart.com:443/TSW-103-07-L-S-Samtec-datasheet-11542832.pdf?src-supplier=Arrow+Electronics; Contact Material: Bronze; Contact Mating Length: 5.842mm; Contact Plating: Gold; Depth: 2.54mm; Housing Color: Black; Housing Material: Polyester; LatestRevisionDate: 17-Jul-2002; LatestRevisionNote: Re-released for DXP Platform.; Lead Free: Lead Free; Lead Pitch: 2.54mm; Lifecycle Status: Production; Max Current Rating: 7.6A; Max Operating Temperature: 125°C; Min Operating Temperature: -55°C; Mounting Technology: Through Hole; Number of Positions: 3; Number of Rows: 1; Orientation: Vertical; Pins: 3; Pricing: 100=0,221, 500=0,172, 1000=0,168, 2500=0,113, 5000=0,0968 (EUR); Radiation Hardening: No; REACH SVHC: No; RoHS: Compliant; Signal Integrity: Connector; Stock: 3721; Supplier: Farnell; Supplier Part Number: 2856685; Termination: Solder; Voltage Rating: 550V; Width: 2.54mm; Library Name: IMCODR Components.SchLib; Publisher: Altium Limited
  JP7-JP9: ClassName: HV_SUPPLY
  JP11: ClassName: HV_DAC
L1 = B82477R4333M100: Inductor Power Shielded Wirewound 33uH 20% 100KHz Ferrite 3.55A 0.05Ohm DCR Automotive T/R; Manufacturer: EPCOS; DC Resistance (DCR): 50mΩ; Max DC Current: 3.38A; Number of Circuits: 1; Saturation Current: 5.2A; Shielding: Yes; Value: 33µH; Case/Package: Nonstandard; Category: Fixed Inductors; China RoHS: Compliant; ClassName: 12V_TO_5V_SUPPLY; Component Kind: Standard; ComponentLink1Description: https://datasheet.octopart.com:443/B82477R4333M100-EPCOS-datasheet-136998880.pdf?src-supplier=IHS; ComponentLink1URL: https://datasheet.octopart.com:443/B82477R4333M100-EPCOS-datasheet-136998880.pdf?src-supplier=IHS; ComponentLink2Description: https://datasheet.octopart.com:443/B82477R4333M100-EPCOS-datasheet-13052764.pdf?src-supplier=EPCOS; ComponentLink2URL: https://datasheet.octopart.com:443/B82477R4333M100-EPCOS-datasheet-13052764.pdf?src-supplier=EPCOS; ComponentLink3Description: https://datasheet.octopart.com:443/B82477R4333M100-EPCOS-datasheet-66576992.pdf?src-supplier=Newark; ComponentLink3URL: https://datasheet.octopart.com:443/B82477R4333M100-EPCOS-datasheet-66576992.pdf?src-supplier=Newark; ComponentLink4Description: https://datasheet.octopart.com:443/B82477R4333M100-EPCOS-datasheet-38086532.pdf?src-supplier=Newark; ComponentLink4URL: https://datasheet.octopart.com:443/B82477R4333M100-EPCOS-datasheet-38086532.pdf?src-supplier=Newark; Composition: Wirewound; Core Material: Ferrite; Depth: 12.5mm; Height: 8.5mm; Height - Seated (Max): 8.509mm; Inductance Tolerance: 20%; Length: 12.5mm; Lifecycle Status: Production; Manufacturer Part Number: B82477R4333M100; Max Current Rating: 3.55A; Max Length: 12.5mm; Max Operating Temperature: 150°C; Max Width: 12.5mm; Military Standard: Not; Min Operating Temperature: -55°C; Mounting Technology: Surface Mount; Number of Terminals: 2; Pricing: 1=1,99, 10=1,633, 100=1,3426 (USD); REACH SVHC: Yes; RMS Current (Irms): 3.38A; RoHS: Compliant; Schedule B: 8504500000; Stock: 1730; Supplier: DigiKey; Supplier Part Number: 495-4546-1-ND; Test Frequency: 100kHz; Tolerance: 20%; Width: 12.5mm; Library Name: IMCODR Components.SchLib
MH1-MH4 = M3 Mounting Hole: Mounting hole for M3 screws; Component Kind: Standard; Library Name: IMCODR Components.SchLib
